# Altium schematic sheet Power.SchDoc(Power)
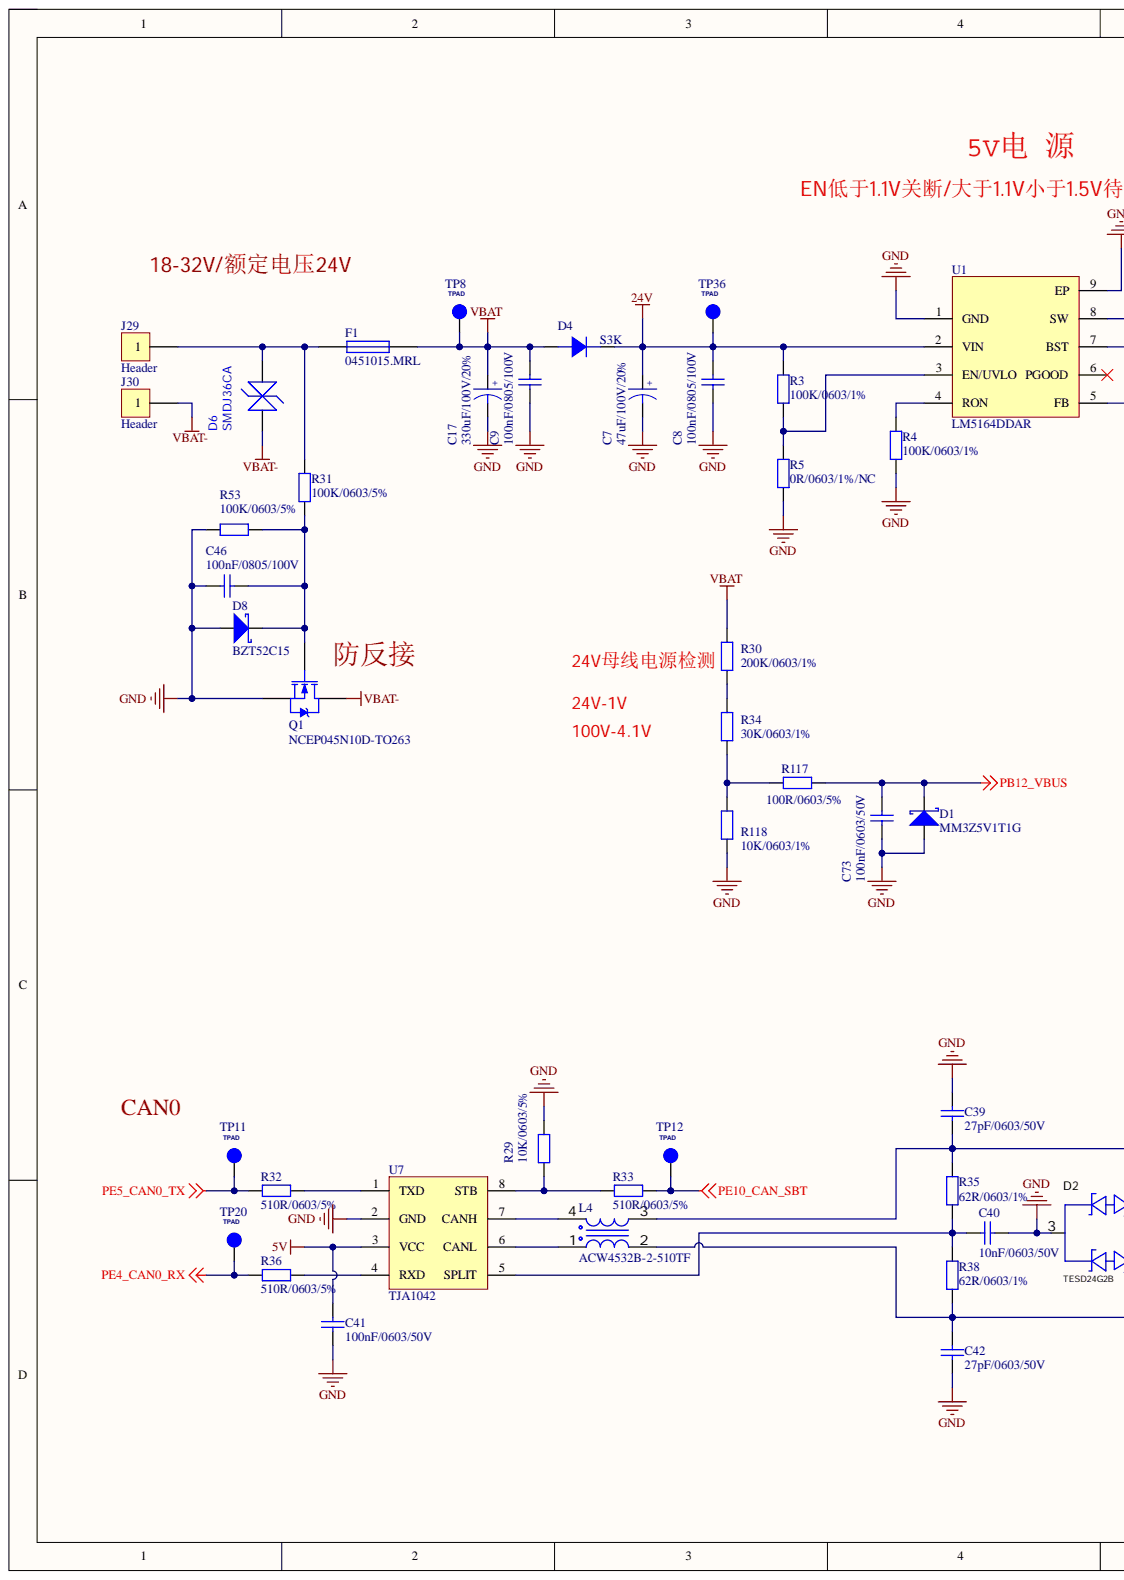
[diagram p0: Power.SchDoc, left]
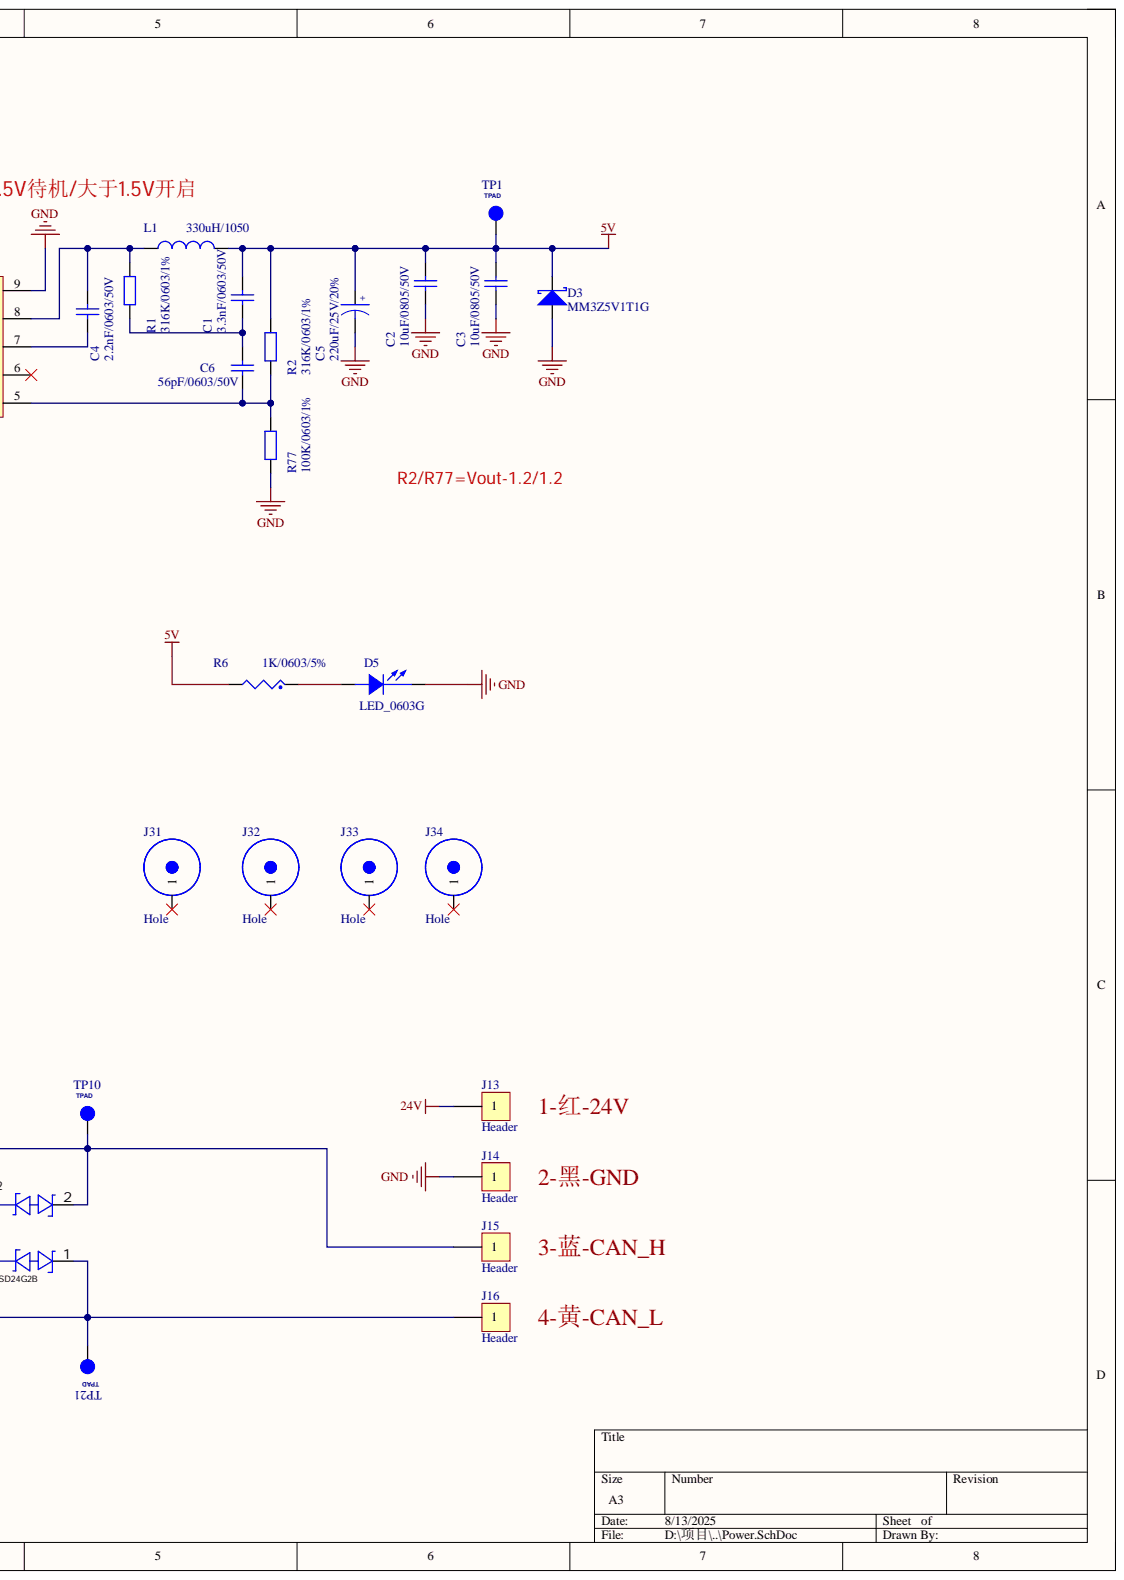
[diagram p1: Power.SchDoc, right]

## Parts
C1  3.3nF/0603/50V  [RC0603]
C2  10uF/0805/50V  [RC0805]
C3  10uF/0805/50V  [RC0805]
C4  2.2nF/0603/50V  [RC0603]
C5  220uF/25V/20%  [CAP-TH_BD6.3-P2.50]
C6  56pF/0603/50V  [RC0603]
C7  47uF/100V/20%  [CAP-TH_BD8.0-P3.50]
C8  100nF/0805/100V  [RC0805]
C9  100nF/0805/100V  [RC0805]
C17  330uF/100V/20%  [CAP-TH_BD12.5-P5.00-D0.6-FD]
C39  27pF/0603/50V  [RC0603]
C40  10nF/0603/50V  [RC0603]
C41  100nF/0603/50V  [RC0603]
C42  27pF/0603/50V  [RC0603]
C46  100nF/0805/100V  [RC0805]
C73  100nF/0603/50V  [RC0603]
D1  MM3Z5V1T1G  [SOD-323]
D2  TESD24G2B  [SOT-23]
D3  MM3Z5V1T1G  [SOD-323]
D4  S3K  [SMC]
D5  LED_0603G  [LED0603]
D6  SMDJ36CA  [SMC(DO-214AB)]
D8  BZT52C15  [SOD-123]
F1  0451015.MRL  [FUSE]
J13  Header  [J]
J14  Header  [J]
J15  Header  [J]
J16  Header  [J]
J29  Header  [J2]
J30  Header  [J2]
J31  Hole  [hole]
J32  Hole  [hole]
J33  Hole  [hole]
J34  Hole  [hole]
L1  330uH/1050  [L1050]
L4  ACW4532B-2-510TF  [FILTER-SMD_4P-L4.5-W3.2-BL_PCAQ4532B]
Q1  NCEP045N10D-TO263  [TO-263-2]
R1  316K/0603/1%  [RC0603]
R2  316K/0603/1%  [RC0603]
R3  100K/0603/1%  [RC0603]
R4  100K/0603/1%  [RC0603]
R5  0R/0603/1%/NC  [RC0603]
R6  1K/0603/5%  [RC0603]
R29  10K/0603/5%  [RC0603]
R30  200K/0603/1%  [RC0603]
R31  100K/0603/5%  [RC0603]
R32  510R/0603/5%  [RC0603]
R33  510R/0603/5%  [RC0603]
R34  30K/0603/1%  [RC0603]
R35  62R/0603/1%  [RC0603]
R36  510R/0603/5%  [RC0603]
R38  62R/0603/1%  [RC0603]
R53  100K/0603/5%  [RC0603]
R77  100K/0603/1%  [RC0603]
R117  100R/0603/5%  [RC0603]
R118  10K/0603/1%  [RC0603]
TP1  NOTE: TPAD  [TP]  (hidden comment: TPAD_030)
TP8  NOTE: TPAD  [TP]  (hidden comment: TPAD_030)
TP10  NOTE: TPAD  [TP]  (hidden comment: TPAD_030)
TP11  NOTE: TPAD  [TP]  (hidden comment: TPAD_030)
TP12  NOTE: TPAD  [TP]  (hidden comment: TPAD_030)
TP20  NOTE: TPAD  [TP]  (hidden comment: TPAD_030)
TP21  NOTE: TPAD  [TP]  (hidden comment: TPAD_030)
TP36  NOTE: TPAD  [TP]  (hidden comment: TPAD_030)
U1  LM5164DDAR  [SO-8-EP]
U7  TJA1042  [SOIC-8]

## Nets
5V: C1.2 C2.2 C3.2 C5.1 C41.2 D3.2 L1.2 R2.2 R6.2 TP1.1 U7.3(VCC)
24V: C7.1 C8.2 D4.2 J13.1 R3.2 TP36.1 U1.2(VIN)
GND: C2.1 C3.1 C5.2 C7.2 C8.1 C9.1 C17.2 C39.1 C40.1 C41.1 C42.1 C46.1 C73.2 D1.1 D2.3 D3.1 D5.2 D8.1 J14.1 Q1.3 R4.1 R5.1 R29.2 R53.1 R77.1 R118.1 U1.1(GND) U1.9(EP) U7.2(GND)
PB12_VBUS: C73.1 D1.2 R117.1
PE4_CAN0_RX: R36.1 TP20.1
PE5_CAN0_TX: R32.1 TP11.1
PE10_CAN_SBT: R33.2 TP12.1
VBAT: C9.2 C17.1 D4.1 F1.2 R30.2 TP8.1
VBAT-: D6.2 J30.1 Q1.2
NetC1_1: C1.1 C6.2 R1.1
NetC4_1: C4.1 U1.7(BST)
NetC4_2: C4.2 L1.1 R1.2 U1.8(SW)
NetC6_1: C6.1 R2.1 R77.2 U1.5(FB)
NetC39_2: C39.2 D2.2 J15.1 L4.3 R35.2 TP10.1
NetC40_2: C40.2 R35.1 R38.2 U7.5(SPLIT)
NetC42_2: C42.2 D2.1 J16.1 L4.2 R38.1 TP21.1
NetC46_2: C46.2 D8.2 Q1.1 R31.2 R53.2
NetD5_1: D5.1 R6.1
NetD6_1: D6.1 F1.1 J29.1 R31.1
NetL4_1: L4.1 U7.6(CANL)
NetL4_4: L4.4 U7.7(CANH)
NetR3_1: R3.1 R5.2 U1.3(EN/UVLO)
NetR4_2: R4.2 U1.4(RON)
NetR29_1: R29.1 R33.1 U7.8(STB)
NetR30_1: R30.1 R34.2
NetR32_2: R32.2 U7.1(TXD)
NetR34_1: R34.1 R117.2 R118.2
NetR36_2: R36.2 U7.4(RXD)

Not connected: J31 1; J32 1; J33 1; J34 1; U1 6(PGOOD)

## Library data of the parts
C1-C4 C6 C8 C9 C39-C42 C46 C73 = C: 贴片电容/MLCC电容; BOM名称: 无极性贴片电容; 联系下单店铺卖家 免费帮你查找封装: 联系下单店铺卖家 免费技术指导; Component Kind: Standard; Library Name: 0.1 - 电阻-电容-电感-保险丝-晶振-晶体-磁珠-电位器-法拉电容-馈通电容.SchLib
C5 = EC_S_470U35V20%-10x10.5: KM1E470M0611; Part NO.: 0102_4771050; PCB Footprint: C-VZH-1010; Spec.: VEJ471M1VTR-1313; Type: 电解电容; VendorID: Rongju; Component Kind: Standard
C7 = EC_S_470U35V20%-10x10.5: KM1E221M0611; Part NO.: 0102_4771050; PCB Footprint: C-VZH-1010; Spec.: VEJ471M1VTR-1313; Type: 电解电容; VendorID: Rongju; Component Kind: Standard
C17 = EC_S_470U35V20%-10x10.5: 电解电容/贴片/10*10.5/470uF/35V/20%/(-40℃～+105℃)/先科/无铅; Part NO.: 0102_4771050; PCB Footprint: C-VZH-1010; Spec.: VEJ471M1VTR-1313; Type: 电解电容; VendorID: Rongju; Component Kind: Standard
D1 D3 D8 = D Schottky: Schottky Diode; Code_JEDEC: DO-214-AA; Component Kind: Standard; LatestRevisionDate: 17-Jul-2002; LatestRevisionNote: Re-released for DXP Platform.; Library Name: Miscellaneous Devices.IntLib; PackageDescription: DO-214-AA/SMB; 2 C-Bend Leads; Body 5.3 x 3.6 mm, inc. leads (LxW); PackageReference: SMB; Published: 8-Jun-2000; Signal Integrity: D Schottky; Simulation: SKYDIODE; Publisher: Altium Limited
D2 = PESD2CAN: Manufacturer: PROTEK; Add into BOM: yes; Breakdown Voltage: 13.3V; Device: PSM712-LF-T7; Maximum Clamping Voltage: 19V; Reverse Stand-Off Voltage (Vrwm): 12V; Type: TVS; Component Kind: Standard; Convert to PCB: yes; Datasheet: https://atta.szlcsc.com/upload/public/pdf/source/20140717/1457707154667.pdf; JLCPCB Part Class: Basic Part; LCSC Part Name: PSM712-LF-T7; Library Name: Schlib1.SchLib; Manufacturer Part: PSM712-LF-T7; Supplier: LCSC; Supplier Footprint: SOT-23; Supplier Part: C32677; Symbol: PSM712-LF-T7
D4 = DIODE_2: PCB Footprint: do-214ab; Component Kind: Standard
D5 = LED_1: 二极管/绿色贴片/0603/19-21/GHC-YP1Q2TY/3T/25mA/5V/(-40℃～+85℃)/品牌亿光/无铅; Part NO.: 0104_0000051P; Type: 二极管; VendorID: 亿光; Component Kind: Standard; PCB Footprint: led_0603; Spec.: 19-21/GHC-YP1Q2TY/3T
D6 = SMDJ36CA: Manufacturer: 宏嘉诚; Add into BOM: yes; Clamping Voltage: 58.1V; Device: SMDJ36CA_C42371556; Peak Pulse Current (Ipp): 51.6A; Peak Pulse Power Dissipation (Ppp): 3kW; Reverse Leakage Current (Ir): 2uA; Reverse Stand-Off Voltage (Vrwm): 36V; Voltage - Breakdown: 44.2V; Component Kind: Standard; Convert to PCB: yes; Datasheet: https://atta.szlcsc.com/upload/public/pdf/source/20250107/4BA1E5948FCD71D4BF2F28E42696AFC0.pdf; JLCPCB Part Class: Extended Part; LCSC Part Name: SMDJ36CA; Manufacturer Part: SMDJ36CA; Operating Temperature: -55℃~+150℃; PCB3D: SMC_L7.1-W6.2-LS8.1-BI; Supplier: LCSC; Supplier Footprint: SMC; Supplier Part: C42371556; Symbol: SMDJ36CA_C42371556; Library Name: ZZL_AC7840_3.0.SchLib
F1 = Fuse 1: Fuse; Component Kind: Standard; LatestRevisionDate: 17-Jul-2002; LatestRevisionNote: Re-released for DXP Platform.; Library Name: Miscellaneous Devices.IntLib; PackageDescription: Thru-Hole; 2 Leads; PackageReference: PIN-W2/E2.8; Published: 8-Jun-2000; Simulation: FUSE; Publisher: Altium Limited
L1 = Inductor: Code_IEC: 1005; Value: 10mH; Code_JEITA: 0402; Component Kind: Standard; Description: Inductor; LatestRevisionDate: 29-May-2009; LatestRevisionNote: IPC-7351 Footprint Added.; Library Name: Miscellaneous Devices.IntLib; PackageDescription: Chip Inductor; PackageReference: 0402-A; Published: 8-Jun-2000; Signal Integrity: Inductor; Simulation: INDUCTOR; Publisher: Altium Limited
Q1 = NMOS-2: N-Channel Power MOSFET; Note: Pin 2 is electrically connected to pin 4.; Component Kind: Standard; ComponentLink1Description: Manufacturer Link; ComponentLink1URL: http://www.irf.com/; DatasheetVersion: PD-9.814; LatestRevisionDate: 17-Jul-2002; LatestRevisionNote: Re-released for DXP Platform.; Library Name: Miscellaneous Devices.IntLib; PackageDescription: TO, Thru-Hole, Vertical, Heatsink Mounted; 3 In-Line Leads; Pitch 2.54 mm; PackageReference: TO-220AB; PackageVersion: Feb-1997; Published: 8-Jun-2000; Simulation: IRF1010; Publisher: Altium Limited
R1-R5 R29-R36 R38 R53 R77 R117 R118 = R: 贴片电阻; 联系下单店铺卖家 免费帮你查找封装: 联系下单店铺卖家 免费技术指导; BOM名称: 贴片电阻; Component Kind: Standard; Library Name: 0.1 - 电阻-电容-电感-保险丝-晶振-晶体-磁珠-电位器-法拉电容-馈通电容.SchLib
R6 = RES: RES MF 10 OHM 1/16W 1% AEC-Q200 0402; AGILE_PN: 470-80978; CAD_APPROVED: Yes; CLASS: DISCRETE; FPLIST: RC0402_25,RC0402_HD,0402_CC; MFG_NAME01: KOA SPEER; MFG_PN01: RK73H1ETTP10R0F; Temp Range: ?5 癈 to +155 癈; Component Kind: Standard; HEIGHT: 0.4MM; PCB Footprint: RC0603; ROHS: Yes; Tolerance: 1%
TP1 TP8 TP10-TP12 TP20 TP21 TP36 = TESTLOOP: TEST POINT PAD 30MIL DIA SMT, NO PART TO ORDER; AGILE_PN: 211-76642; CAD_APPROVED: Yes; CLASS: IO; MFG_NAME01: NOTACOMPONENT; PCB Footprint: test_smd_1_2mm; ROOM: POWER SUPPLY_LR; 3D_Mechanical_Footprint_File: http://fcl.am.freescale.net/ALLEGRO/step/tpad_030.STEP; Component Kind: Standard; HEIGHT: 0.1MM; MFG_PN01: NOTACOMPONENT; ROHS: Yes
J13-J16 J29 J30 = Header: Component Kind: Standard; Library Name: ZZL_ZY_AC7840.SchLib
J31-J34 = Hole: Component Kind: Standard; Library Name: High_Pressure_2507.SchLib
L4 = ACW4532B-2-510TF: Component Kind: Standard; Library Name: High_Pressure.SchLib
U1 = LM5164DDAR: Component Kind: Standard; Library Name: ZZL_ZY_AC7840.SchLib
U7 = TJA1042: Component Kind: Standard; Library Name: Schlib1.SchLib
